# Altium schematic sheet FPGA_Config.SchDoc
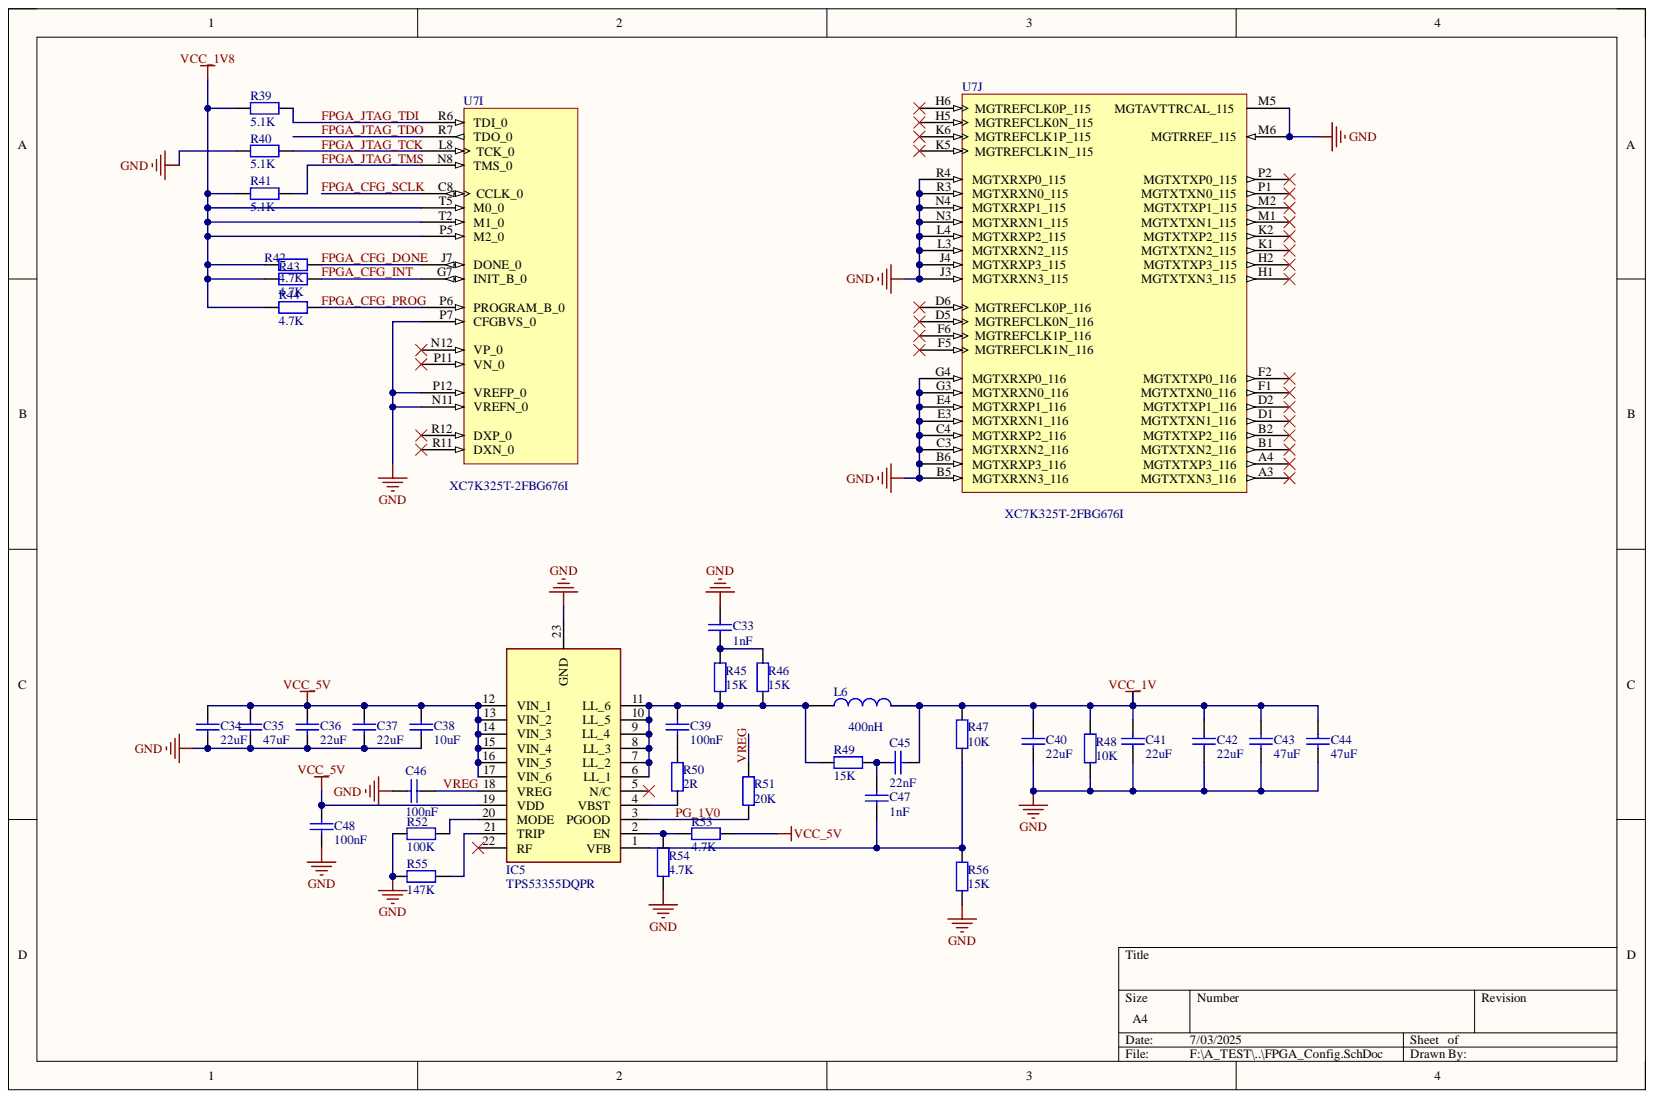
[diagram p0: FPGA_Config.SchDoc]

## Parts
C33  1nF  [C 0402_L]
C34  22uF  [C 0805_L]
C35  47uF  [C 0805_L]
C36  22uF  [C 0805_L]
C37  22uF  [C 0805_L]
C38  10uF  [C 0603_L]
C39  100nF  [C 0402_L]
C40  22uF  [C 0805_L]
C41  22uF  [C 0805_L]
C42  22uF  [C 0805_L]
C43  47uF  [C 0805_L]
C44  47uF  [C 0805_L]
C45  22nF  [C 0402_L]
C46  100nF  [C 0402_L]
C47  1nF  [C 0402_L]
C48  100nF  [C 0402_L]
IC5  TPS53355DQPR  [SON50P500X600X150-23N]
L6  400nH  [L-SMD-0420]
R39  5.1K  [R 0402_L]
R40  5.1K  [R 0402_L]
R41  5.1K  [R 0402_L]
R42  4.7K  [R 0402_L]
R43  4.7K  [R 0402_L]
R44  4.7K  [R 0402_L]
R45  15K  [R 0402_L]
R46  15K  [R 0402_L]
R47  10K  [R 0402_L]
R48  10K  [R 0402_L]
R49  15K  [R 0402_L]
R50  2R  [R 0402_L]
R51  20K  [R 0402_L]
R52  100K  [R 0402_L]
R53  4.7K  [R 0402_L]
R54  4.7K  [R 0402_L]
R55  147K  [R 0402_L]
R56  15K  [R 0402_L]
U7  XC7K325T-2FBG676I  [FFG676]  (parts I,J)

## Nets
GND: C33.2 C34.1 C35.1 C36.1 C37.1 C38.1 C40.2 C41.2 C42.2 C43.2 C44.2 C46.2 C48.2 IC5.23(GND) R40.1 R48.1 R52.1 R54.1 R55.1 R56.1 U7.B5(MGTXRXN3_116) U7.B6(MGTXRXP3_116) U7.C3(MGTXRXN2_116) U7.C4(MGTXRXP2_116) U7.E3(MGTXRXN1_116) U7.E4(MGTXRXP1_116) U7.G3(MGTXRXN0_116) U7.G4(MGTXRXP0_116) U7.J3(MGTXRXN3_115) U7.J4(MGTXRXP3_115) U7.L3(MGTXRXN2_115) U7.L4(MGTXRXP2_115) U7.M5(MGTAVTTRCAL_115) U7.M6(MGTRREF_115) U7.N3(MGTXRXN1_115) U7.N4(MGTXRXP1_115) U7.N11(VREFN_0) U7.P7(CFGBVS_0) U7.P12(VREFP_0) U7.R3(MGTXRXN0_115) U7.R4(MGTXRXP0_115)
VCC_1V: C40.1 C41.1 C42.1 C43.1 C44.1 C45.1 L6.2 R47.2 R48.2
VCC_1V8: R39.1 R41.1 R42.2 R43.2 R44.2 U7.P5(M2_0) U7.T2(M1_0) U7.T5(M0_0)
VCC_5V: C34.2 C35.2 C36.2 C37.2 C38.2 C48.1 IC5.12(VIN_1) IC5.13(VIN_2) IC5.14(VIN_3) IC5.15(VIN_4) IC5.16(VIN_5) IC5.17(VIN_6) IC5.19(VDD) R53.2
FPGA_CFG_DONE: R42.1 U7.J7(DONE_0)
FPGA_CFG_INT: R43.1 U7.G7(INIT_B_0)
FPGA_CFG_PROG: R44.1 U7.P6(PROGRAM_B_0)
FPGA_CFG_SCLK: U7.C8(CCLK_0)
FPGA_JTAG_TCK: R40.2 U7.L8(TCK_0)
FPGA_JTAG_TDI: R39.2 U7.R6(TDI_0)
FPGA_JTAG_TDO: U7.R7(TDO_0)
FPGA_JTAG_TMS: R41.2 U7.N8(TMS_0)
PG_1V0: IC5.3(PGOOD) R51.1
VREG: C46.1 IC5.18(VREG) R51.2
NetC33_1: C33.1 R45.2 R46.2
NetC39_1: C39.1 R50.2
NetC39_2: C39.2 IC5.6(LL_1) IC5.7(LL_2) IC5.8(LL_3) IC5.9(LL_4) IC5.10(LL_5) IC5.11(LL_6) L6.1 R45.1 R46.1 R49.2
NetC45_2: C45.2 C47.2 R49.1
NetC47_1: C47.1 IC5.1(VFB) R47.1 R56.2
NetIC5_2: IC5.2(EN) R53.1 R54.2
NetIC5_4: IC5.4(VBST) R50.1
NetIC5_20: IC5.20(MODE) R52.2
NetIC5_21: IC5.21(TRIP) R55.2

Not connected: IC5 5(N/C) 22(RF); U7 A3(MGTXTXN3_116) A4(MGTXTXP3_116) B1(MGTXTXN2_116) B2(MGTXTXP2_116) D1(MGTXTXN1_116) D2(MGTXTXP1_116) D5(MGTREFCLK0N_116) D6(MGTREFCLK0P_116) F1(MGTXTXN0_116) F2(MGTXTXP0_116) F5(MGTREFCLK1N_116) F6(MGTREFCLK1P_116) H1(MGTXTXN3_115) H2(MGTXTXP3_115) H5(MGTREFCLK0N_115) H6(MGTREFCLK0P_115) K1(MGTXTXN2_115) K2(MGTXTXP2_115) K5(MGTREFCLK1N_115) K6(MGTREFCLK1P_115) M1(MGTXTXN1_115) M2(MGTXTXP1_115) N12(VP_0) P1(MGTXTXN0_115) P2(MGTXTXP0_115) P11(VN_0) R11(DXN_0) R12(DXP_0)

## Library data of the parts
C33-C48 = Cap: 贴片电容; Component Kind: Standard; Library Name: A_Schlib.SchLib
  C33 C47: value: 1nF
  C34 C36 C37 C40-C42: value: 22uF
  C35 C43 C44: value: 47uF
  C38: value: 10uF
  C39 C46 C48: value: 100nF
  C45: value: 22nF
IC5 = TPS53355DQPR: Integrated Circuit; Manufacturer_Name: Texas Instruments; Arrow Part Number: TPS53355DQPR; Arrow Price/Stock: https://www.arrow.com/en/products/tps53355dqpr/texas-instruments; Component Kind: Standard; Datasheet Link: http://www.ti.com/lit/gpn/tps53355; Height: 1.5mm; Manufacturer_Part_Number: TPS53355DQPR; Mouser Part Number: 595-TPS53355DQPR; Mouser Price/Stock: https://www.mouser.co.uk/ProductDetail/Texas-Instruments/TPS53355DQPR?qs=UMk2QrKu%2FwxMH3BkuY4bHg%3D%3D
L6 = 电感: 包含功率; Component Kind: Standard; Library Name: A_Schlib.SchLib
R39-R56 = Res: 通用; Component Kind: Standard; Library Name: A_Schlib.SchLib
  R39-R41: value: 5.1K
  R42-R44 R53 R54: value: 4.7K
  R45 R46 R49 R56: value: 15K
  R47 R48: value: 10K
  R50: value: 2R
  R51: value: 20K
  R52: value: 100K
  R55: value: 147K
U7 = XC7K325T-2FBG676I: Kintex-7 FPGA, 500 User I/Os, 8 GTX, 676-Ball BGA, Speed Grade 2, Industrial Grade, Pb-Free; Manufacturer: Xilinx; GTX: 8; SelectIO HP: 150; SelectIO HR: 250; Speed Grade: -2; Code_JEDEC: MS-034; Component Kind: Standard; ComponentLink1Description: Datasheet; ComponentLink1URL: http://www.xilinx.com/support/documentation/data_sheets/ds180_7Series_Overview.pdf; ComponentLink2Description: Package Specification; ComponentLink2URL: http://www.xilinx.com/support/documentation/user_guides/ug475_7Series_Pkg_Pinout.pdf; DatasheetVersion: v1.12; Generic Part Number: XC7K325T; Library Name: Xilinx Kintex-7.IntLib; Mounting Technology: Surface Mount; PackageDescription: Flip-Chip Lidless BGA, Body 27 x 27 mm, 1.0 mm Pitch; PackageReference: FBG676; PackageVersion: Rev 1.8; PartNumber: XC7K325T-2FBG676I; RoHS: Pb-Free
